# Altium schematic sheet [9]_ID_Serial.SchDoc([9]_ID_Serial)
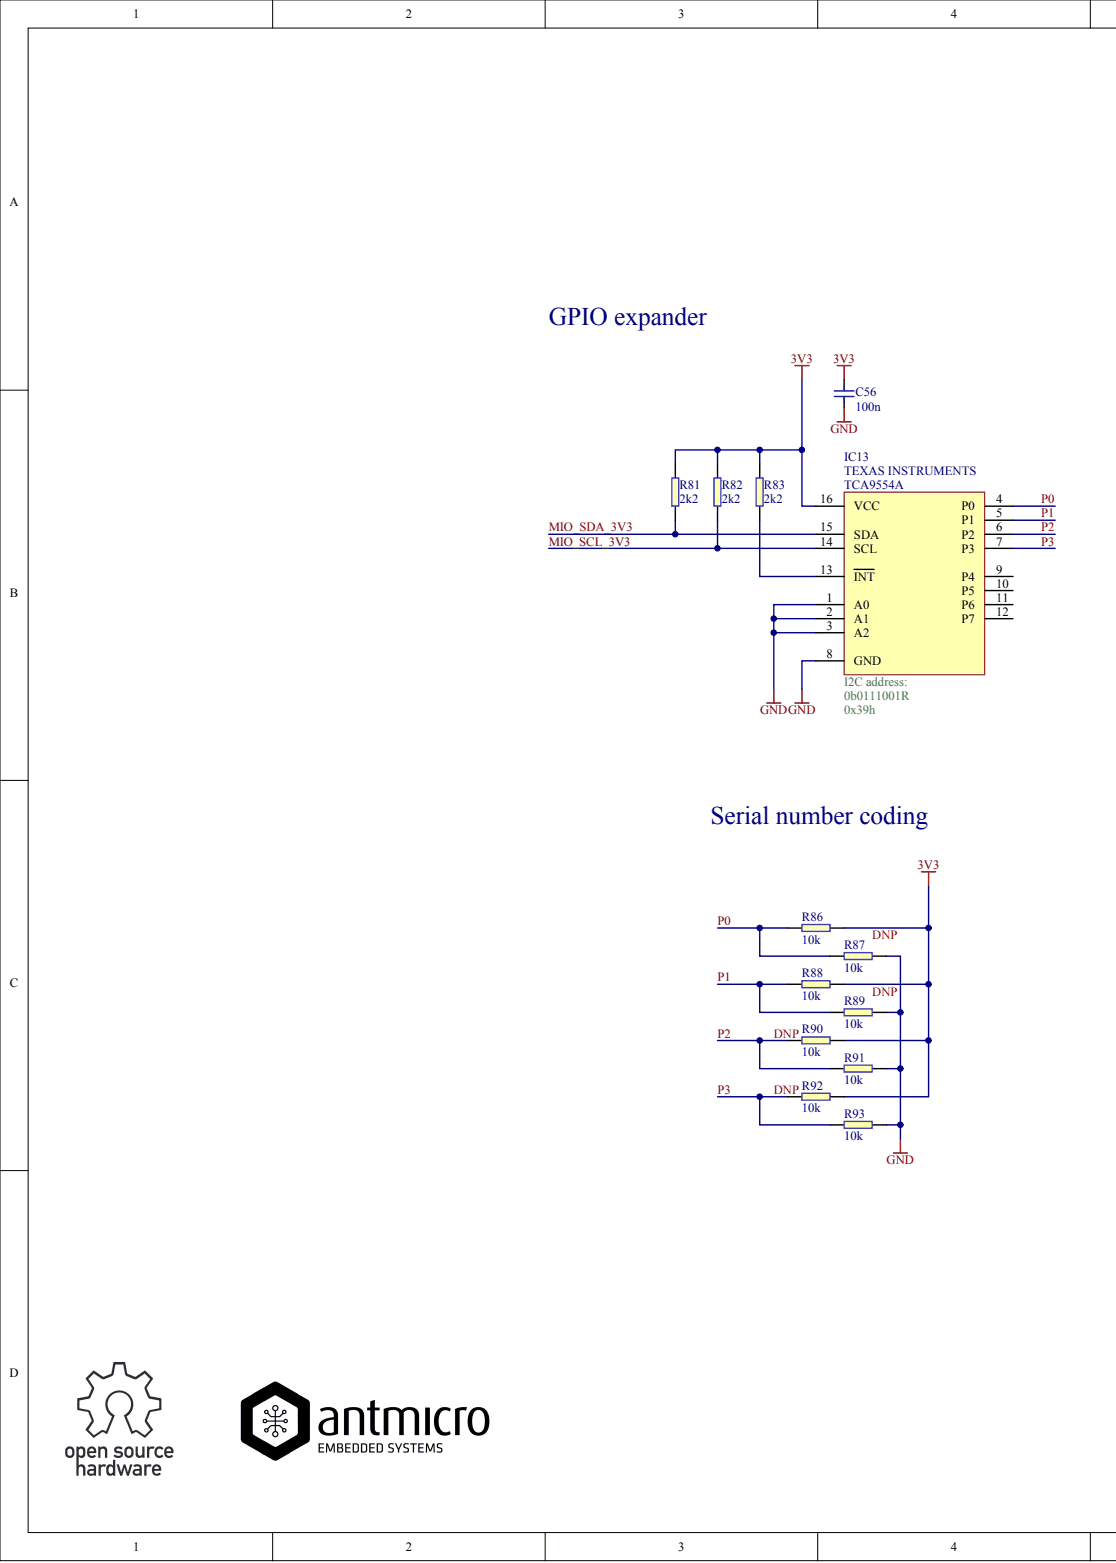
[diagram p0: [9]_ID_Serial.SchDoc, left]
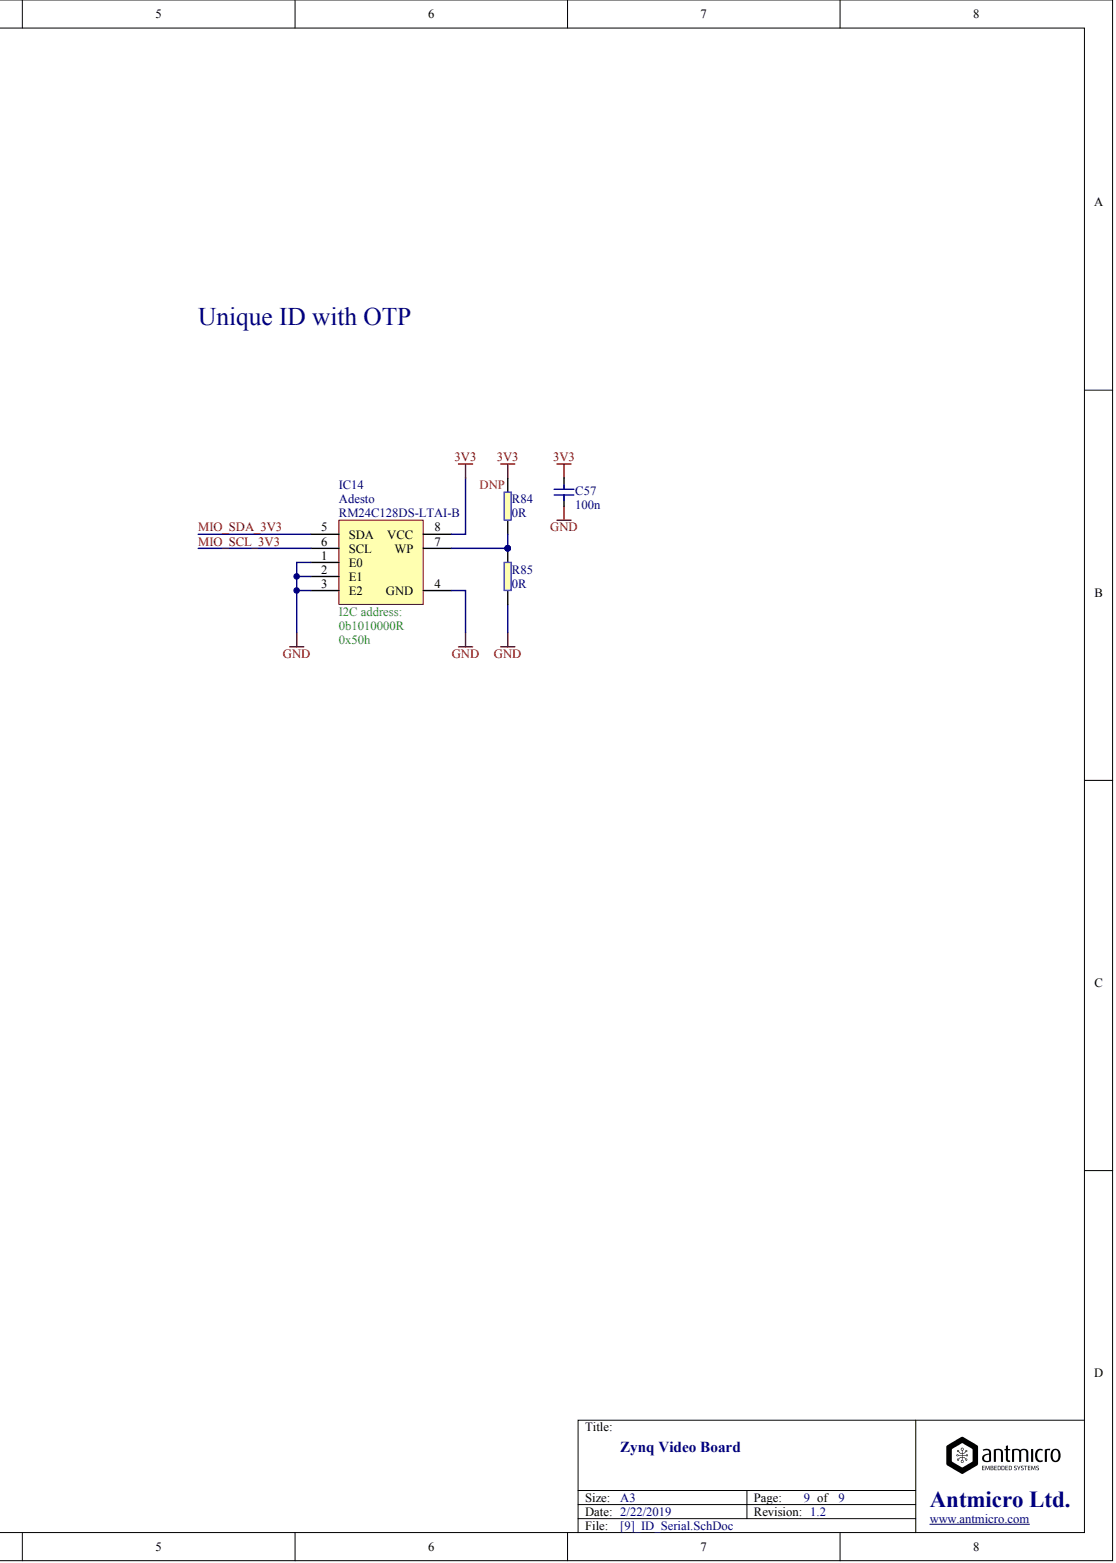
[diagram p1: [9]_ID_Serial.SchDoc, right]

## Parts
C56  100n
C57  100n
IC13  Manufacturer: TEXAS INSTRUMENTS  (hidden comment: TCA9554A)
IC14  Manufacturer: Adesto  (hidden comment: RM24C128DS-LTAI-B)
R81  2k2
R82  2k2
R83  2k2
R84  0R
R85  0R
R86  10k
R87  10k
R88  10k
R89  10k
R90  10k
R91  10k
R92  10k
R93  10k

## Nets
3V3: C56.2 C57.2 IC13.16(VCC) IC14.8(VCC) R81.2 R82.2 R83.2 R84.2 R86.2 R88.2 R90.2 R92.2
GND: C56.1 C57.1 IC13.1(A0) IC13.2(A1) IC13.3(A2) IC13.8(GND) IC14.1(E0) IC14.2(E1) IC14.3(E2) IC14.4(GND) R85.1 R87.2 R89.2 R91.2 R93.2
MIO_SCL_3V3: IC13.14(SCL) IC14.6(SCL) R82.1
MIO_SDA_3V3: IC13.15(SDA) IC14.5(SDA) R81.1
P0: IC13.4(P0) R86.1 R87.1
P1: IC13.5(P1) R88.1 R89.1
P2: IC13.6(P2) R90.1 R91.1
P3: IC13.7(P3) R92.1 R93.1
NetIC13_13: IC13.13(INT) R83.1
NetIC14_7: IC14.7(WP) R84.1 R85.2

Not connected: IC13 9(P4) 10(P5) 11(P6) 12(P7)

## Library data of the parts
C56 C57 = (no symbol name): Part Number: C_100n_0402; Manufacturer: MULTICOMP; Part Symbol: MC0402X104M100CT; Voltage: 10V; Tolerance: +-20%; Value: 100n
R81-R83 = (no symbol name): Part Number: R_2k2_0402; Manufacturer: MULTICOMP; Part Symbol: MCWR04X2201FTL; Tolerance: 5%; Value: 2k2
R84 R85 = (no symbol name): Part Number: R_0R_0402; Manufacturer: MULTICOMP; Part Symbol: MCMR04X000 PTL; Value: 0R
R86-R93 = (no symbol name): Part Number: R_10k_0402; Manufacturer: MULTICOMP; Part Symbol: MCMR04X1002FTL; Tolerance: 1%; Value: 10k
IC13 IC14 = (no symbol name)
  IC13: Part Number: TCA9554A; Value: TCA9554A; Part Symbol: TCA9554A
  IC14: Part Number: RM24C128DS; Value: RM24C128DS-LTAI-B; Part Symbol: RM24C128DS-LTAI-B
